# Altium schematic sheet Interface.SchDoc
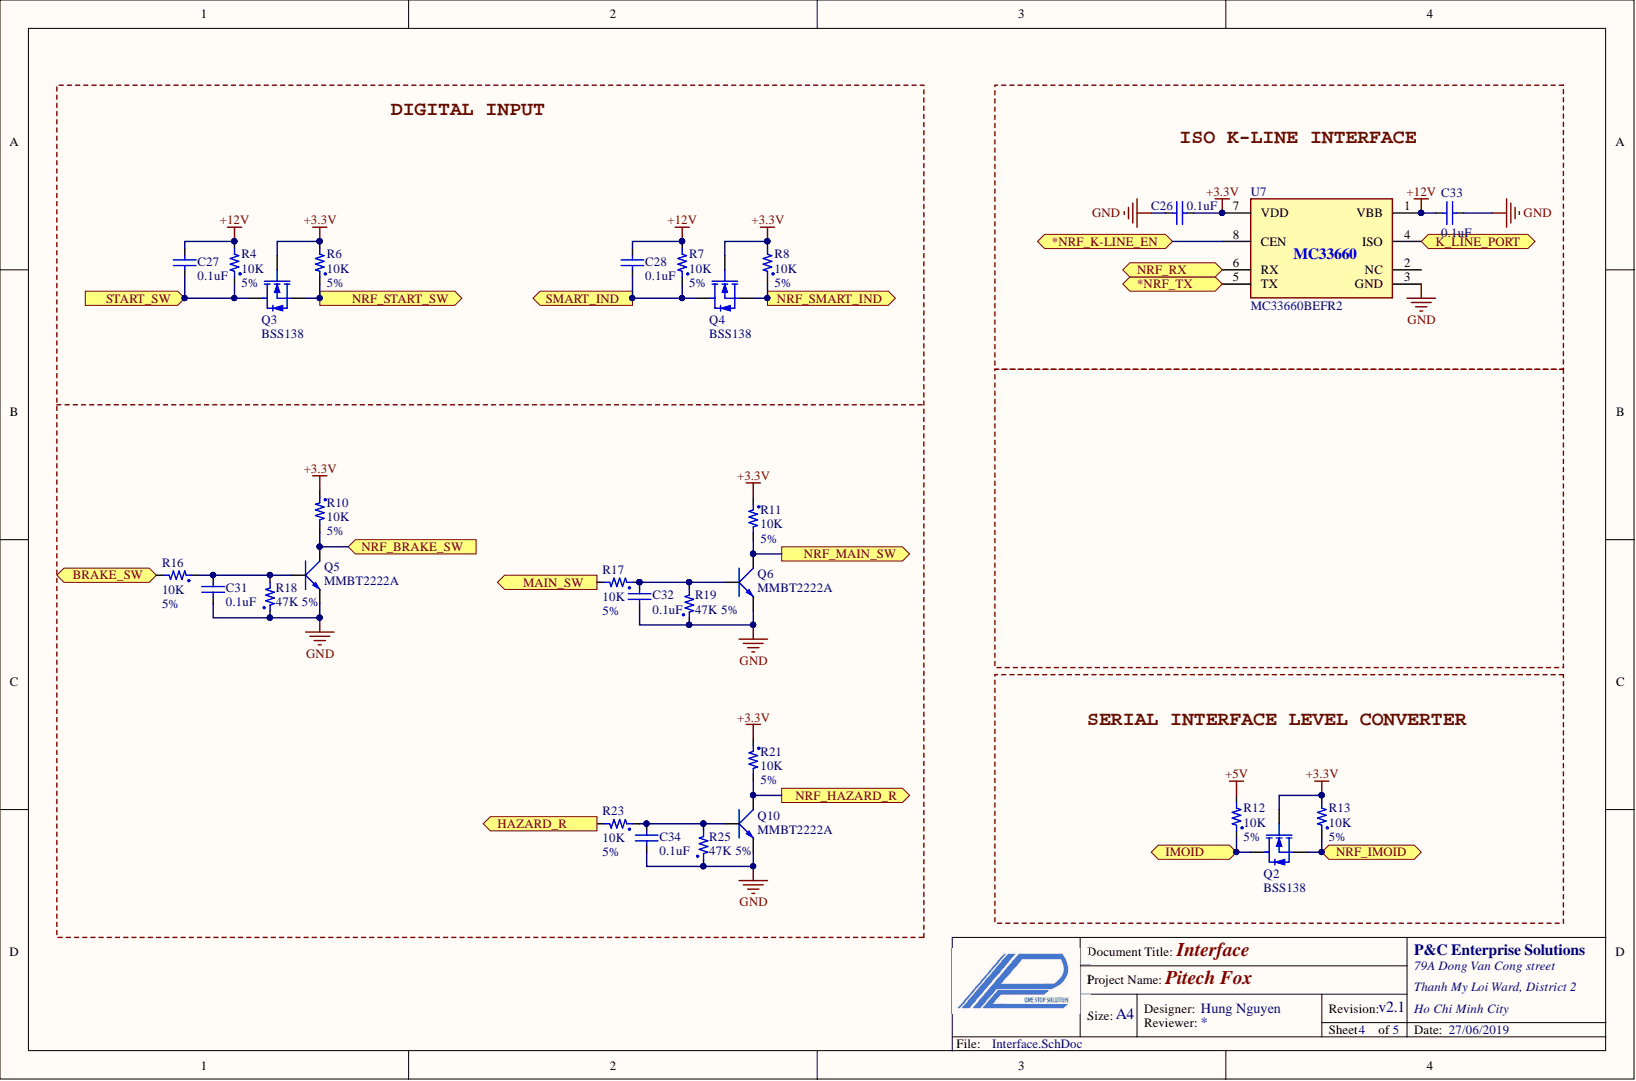
[diagram p0: Interface.SchDoc]

## Parts
C26  0.1uF  [C0603 (1608 metric)]
C27  0.1uF  [C0603 (1608 metric)]
C28  0.1uF  [C0603 (1608 metric)]
C31  0.1uF  [C0603 (1608 metric)]
C32  0.1uF  [C0603 (1608 metric)]
C33  0.1uF  [C0603 (1608 metric)]
C34  0.1uF  [C0603 (1608 metric)]
Q2  BSS138  [FET_SOT23-3]
Q3  BSS138  [FET_SOT23-3]
Q4  BSS138  [FET_SOT23-3]
Q5  MMBT2222A  [SOT23]
Q6  MMBT2222A  [SOT23]
Q10  MMBT2222A  [SOT23]
R4  10K  Tolerance: 5%  [R0603 (1608 Metric)]
R6  10K  Tolerance: 5%  [R0603 (1608 Metric)]
R7  10K  Tolerance: 5%  [R0603 (1608 Metric)]
R8  10K  Tolerance: 5%  [R0603 (1608 Metric)]
R10  10K  Tolerance: 5%  [R0603 (1608 Metric)]
R11  10K  Tolerance: 5%  [R0603 (1608 Metric)]
R12  10K  Tolerance: 5%  [R0603 (1608 Metric)]
R13  10K  Tolerance: 5%  [R0603 (1608 Metric)]
R16  10K  Tolerance: 5%  [R0603 (1608 Metric)]
R17  10K  [R0603 (1608 Metric)]
R18  47K 5%  value 47K  [R0603 (1608 Metric)]
R19  47K 5%  value 47K  [R0603 (1608 Metric)]
R21  10K  Tolerance: 5%  [R0603 (1608 Metric)]
R23  10K  Tolerance: 5%  [R0603 (1608 Metric)]
R25  47K 5%  value 47K  [R0603 (1608 Metric)]
U7  MC33660BEFR2  [MC33660_SOIC8]

## Ports
*NRF_K-LINE_EN, *NRF_TX, BRAKE_SW, HAZARD_R, IMOID, K_LINE_PORT, MAIN_SW, NRF_BRAKE_SW, NRF_HAZARD_R, NRF_IMOID, NRF_MAIN_SW, NRF_RX, NRF_SMART_IND, NRF_START_SW, SMART_IND, START_SW

## Nets
+3.3V: C26.2 Q2.1 Q3.1 Q4.1 R6.2 R8.2 R10.1 R11.1 R13.2 R21.1 U7.7(VDD)
+5V: R12.2
+12V: C27.2 C28.2 C33.2 R4.2 R7.2 U7.1(VBB)
GND: C26.1 C31.1 C32.1 C33.1 C34.1 Q5.2 Q6.2 Q10.2 R18.1 R19.1 R25.1 U7.3(GND)
*NRF_K-LINE_EN: U7.8(CEN) ; port *NRF_K-LINE_EN
*NRF_TX: U7.5(TX) ; port *NRF_TX
BRAKE_SW: R16.2 ; port BRAKE_SW
HAZARD_R: R23.2 ; port HAZARD_R
IMOID: Q2.3 R12.1 ; port IMOID
K_LINE_PORT: U7.4(ISO) ; port K_LINE_PORT
MAIN_SW: R17.2 ; port MAIN_SW
NRF_BRAKE_SW: Q5.3 R10.2 ; port NRF_BRAKE_SW
NRF_HAZARD_R: Q10.3 R21.2 ; port NRF_HAZARD_R
NRF_IMOID: Q2.2 R13.1 ; port NRF_IMOID
NRF_MAIN_SW: Q6.3 R11.2 ; port NRF_MAIN_SW
NRF_RX: U7.6(RX) ; port NRF_RX
NRF_SMART_IND: Q4.2 R8.1 ; port NRF_SMART_IND
NRF_START_SW: Q3.2 R6.1 ; port NRF_START_SW
SMART_IND: C28.1 Q4.3 R7.1 ; port SMART_IND
START_SW: C27.1 Q3.3 R4.1 ; port START_SW
NetC31_2: C31.2 Q5.1 R16.1 R18.2
NetC32_2: C32.2 Q6.1 R17.1 R19.2
NetC34_2: C34.2 Q10.1 R23.1 R25.2

Not connected: U7 2(NC)

## Library data of the parts
C26-C28 C31-C34 = TMK107BJ104KA-T: Multilayer Ceramic Capacitors MLCC - SMD/SMT 0.1uF 25V X7R +/-10% 0603 Gen Purp; Mfr: Taiyo Yuden; Tolerance: 10%; Case: 0603 (1608 metric); Component Kind: Standard; Dielectric: X7R; Library Name: CeramicCapacitor.SchLib; Mfr Part Number: TMK107BJ104KA-T; Supplier 1: Mouser; Supplier Part Number 1: 963-TMK107BJ104KA-T; Value: 0.1uF; Voltage: 25V
Q2-Q4 = BSS138: MOSFET MOSFT 50V 0.22A SOT23; Mfr: ON Semiconductor / Fairchild; Id - Continuous Drain Current: 220mA; Value: 50V, 220mA; Vgs - Gate-Source Voltage: 20V; Component Kind: Standard; Library Name: MOSFET.SchLib; Mfr Part Number: BSS138; Supplier 1: Mouser; Supplier Part Number 1: 512-BSS138; Vds - Drain-Source Breakdown Voltage: 50V
Q5 Q6 Q10 = MMBT2222AWT1G: Bipolar Transistors - BJT SS SOT23 GP XSTR NPN 40V; Mfr: ON Semiconductor; Component Kind: Standard; Library Name: BJT.SchLib; Mfr Part Number: MMBT2222AWT1G; Supplier 1: Mouser; Supplier Part Number 1: 863-MMBT2222AWT1G
R4 R6-R8 R10-R13 R16 R17 R21 R23 = RC0603JR-0710KL: Thick Film Resistors - SMD 10K OHM 5% Case 0603; Mfr: Yageo; Case: 0603 (1608 metric); Component Kind: Standard; Library Name: ChipResistor.SchLib; Mfr Part Number: RC0603JR-0710KL; Supplier 1: Mouser; Supplier Part Number 1: 603-RC0603JR-0710KL; Value: 10K
  R17: Tolerance: 5%
R18 R19 R25 = RC0603JR-0747KL: Thick Film Resistors - SMD 47K OHM 5% Case 0603; Mfr: Yageo; Case: 0603 (1608 metric); Component Kind: Standard; Library Name: ChipResistor.SchLib; Mfr Part Number: RC0603JR-0747KL; Supplier 1: Mouser; Supplier Part Number 1: 603-RC0603JR-0747KL; Tolerance: 5%
U7 = MC33660BEFR2: ISO K line serial link interface; Mfr: NXP; Component Kind: Standard; Library Name: Interface.SchLib; Mfr Part Number: MC33660BEFR2; Supplier 1: Digi-key; Supplier Part Number 1: 568-15198-1-ND
